# Altium schematic sheet CAPPA-B-DELUXE-esp.SchDoc(CAPPA-B-DELUXE-esp)
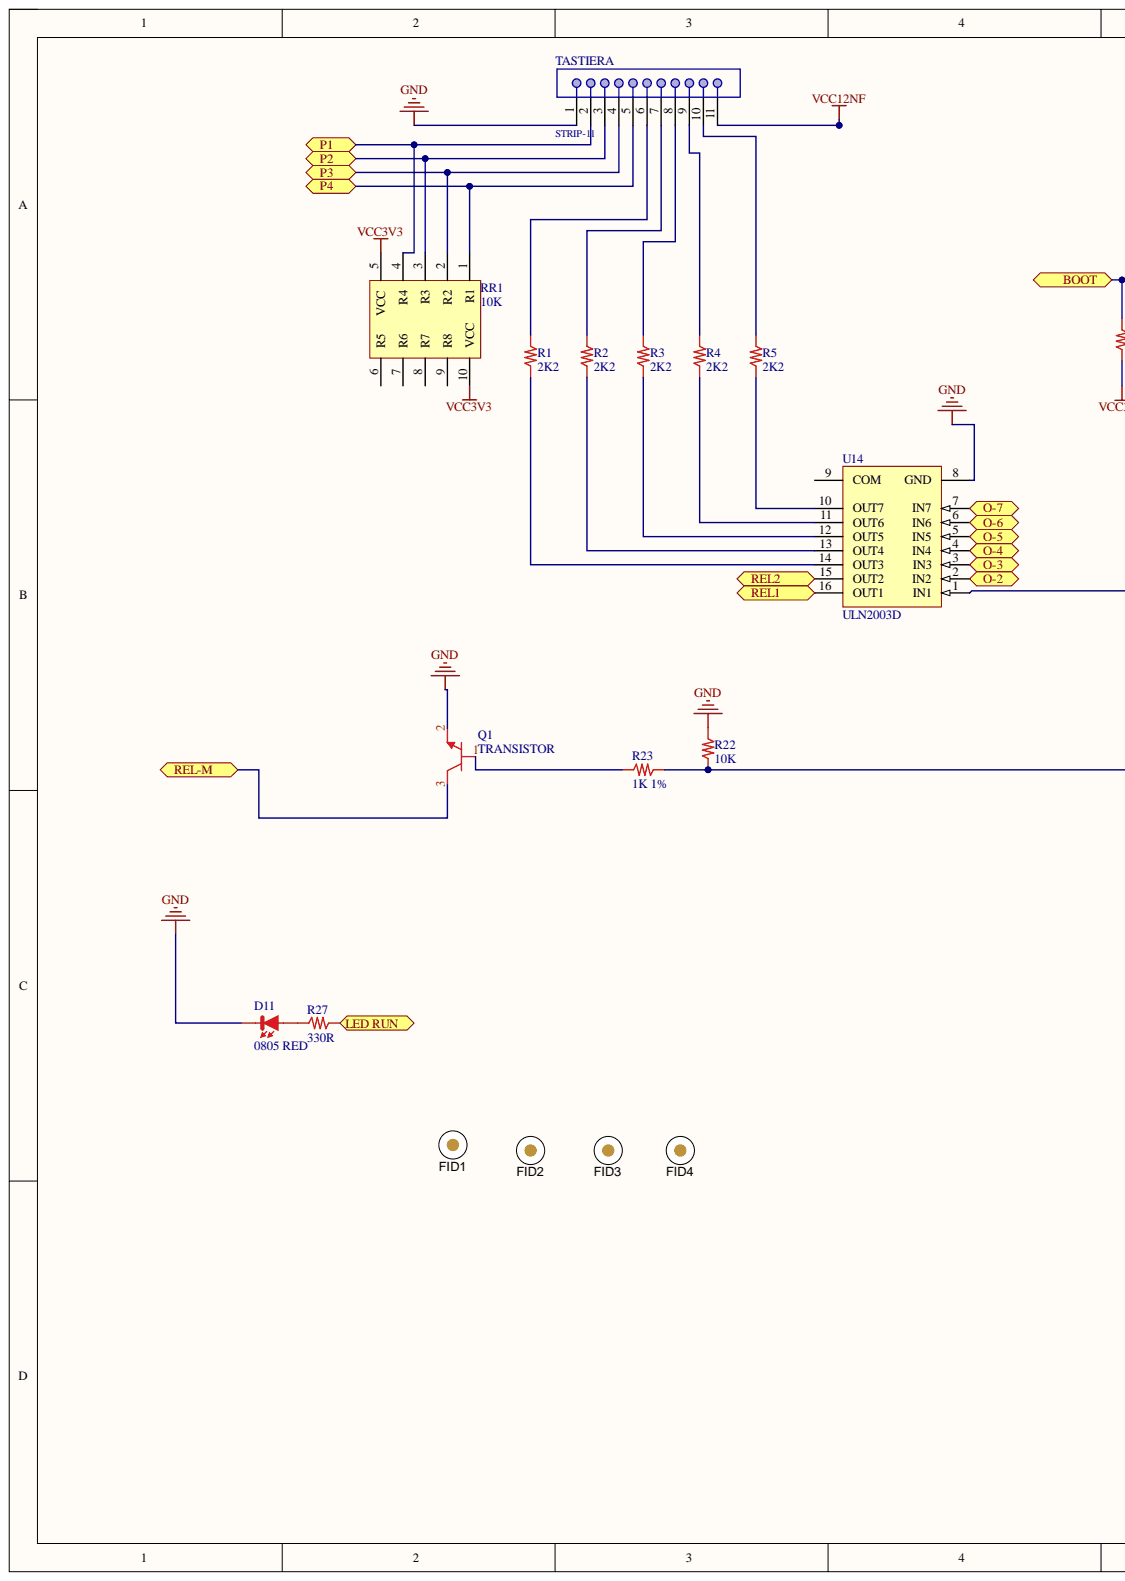
[diagram p0: CAPPA-B-DELUXE-esp.SchDoc, left]
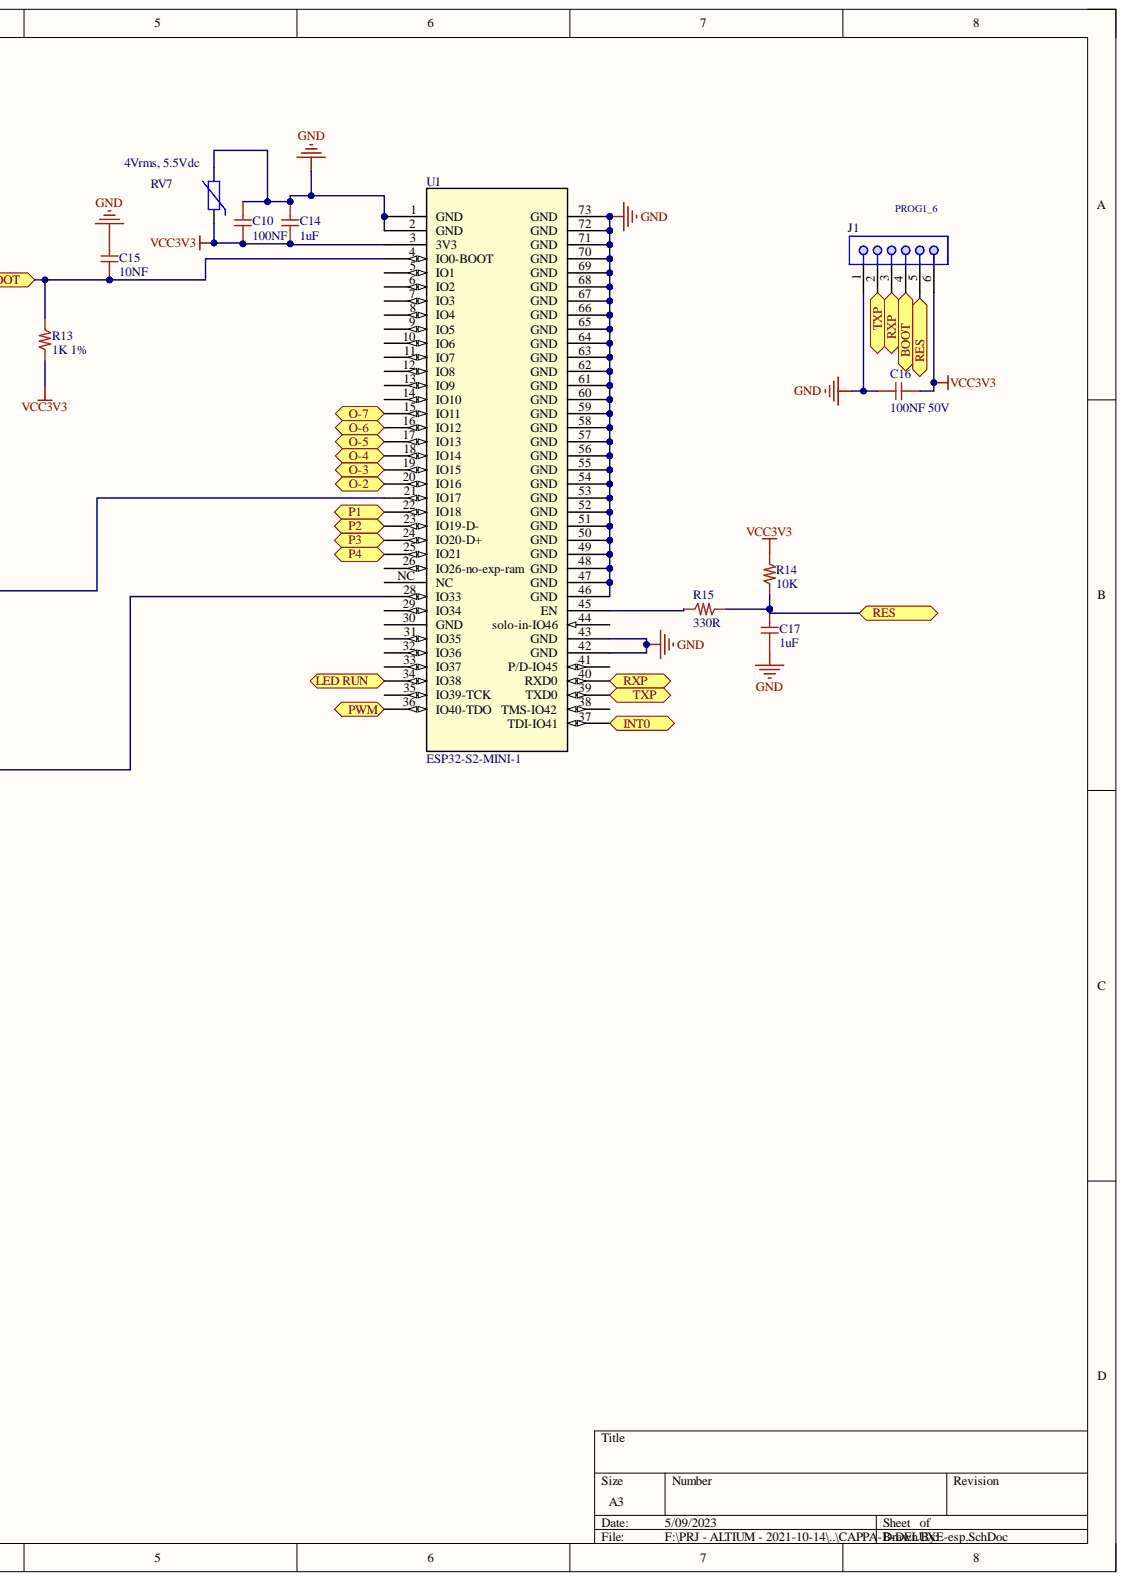
[diagram p1: CAPPA-B-DELUXE-esp.SchDoc, right]

## Parts
C10  100NF  [0402_C]
C14  1uF  [0603_c]
C15  10NF  [0402_C]
C16  100NF 50V  [0603_c]
C17  1uF  [0603_c]
D11  0805 RED  [0805_Red]
FID1  Fiducial  [Fiducial10-20]  (value not drawn)
FID2  Fiducial  [Fiducial10-20]  (value not drawn)
FID3  Fiducial  [Fiducial10-20]  (value not drawn)
FID4  Fiducial  [Fiducial10-20]  (value not drawn)
J1  PROG1_6  [PROG1 - TR -B]
Q1  TRANSISTOR  [SOT23]
R1  2K2  [1206_R]
R2  2K2  [1206_R]
R3  2K2  [1206_R]
R4  2K2  [1206_R]
R5  2K2  [1206_R]
R13  1K 1%  [0402_R]
R14  10K  [0603_R]
R15  330R  [0603_R]
R22  10K  [0603_R]
R23  1K 1%  [0603_R]
R27  330R  [0603_R]
RR1  10K  [RR8+1-SMD]
RV7  4Vrms, 5.5Vdc  DC Operating Voltage: 5.5Vdc  [WE-VS_0603]
TASTIERA  STRIP-11  [STRIP-11]
U1  ESP32-S2-MINI-1  [ESP32-S2-MINI-1]
U14  ULN2003D  [SO16_N]

## Ports
BOOT, INT0, LED RUN, O-2, O-3, O-4, O-5, O-6, O-7, P1, P2, P3, P4, PWM, REL1, REL2, REL-M, RES, RXP, TXP

## Nets
GND: C10.2 C14.2 C15.2 C16.2 C17.1 D11.K J1.1 Q1.2 R22.1 RV7.2 TASTIERA.1 U1.1(GND) U1.2(GND) U1.42(GND) U1.43(GND) U1.46(GND) U1.47(GND) U1.48(GND) U1.49(GND) U1.50(GND) U1.51(GND) U1.52(GND) U1.53(GND) U1.54(GND) U1.55(GND) U1.56(GND) U1.57(GND) U1.58(GND) U1.59(GND) U1.60(GND) U1.61(GND) U1.62(GND) U1.63(GND) U1.64(GND) U1.65(GND) U1.66(GND) U1.67(GND) U1.68(GND) U1.69(GND) U1.70(GND) U1.71(GND) U1.72(GND) U1.73(GND) U14.8(GND)
VCC3V3: C10.1 C14.1 C16.1 J1.6 R13.1 R14.1 RR1.5(VCC) RR1.10(VCC) RV7.1 U1.3(3V3)
VCC12NF: TASTIERA.11
BOOT: C15.1 J1.4 R13.2 U1.4(IO0-BOOT) ; port BOOT
INT0: U1.37(TDI-IO41) ; port INT0
LED RUN: R27.1 U1.34(IO38) ; port LED RUN
O-2: U1.20(IO16) U14.2(IN2) ; port O-2
O-3: U1.19(IO15) U14.3(IN3) ; port O-3
O-4: U1.18(IO14) U14.4(IN4) ; port O-4
O-5: U1.17(IO13) U14.5(IN5) ; port O-5
O-6: U1.16(IO12) U14.6(IN6) ; port O-6
O-7: U1.15(IO11) U14.7(IN7) ; port O-7
P1: RR1.4(R4) TASTIERA.2 U1.22(IO18) ; port P1
P2: RR1.3(R3) TASTIERA.3 U1.23(IO19-D-) ; port P2
P3: RR1.2(R2) TASTIERA.4 U1.24(IO20-D+) ; port P3
P4: RR1.1(R1) TASTIERA.5 U1.25(IO21) ; port P4
PWM: U1.36(IO40-TDO) ; port PWM
REL1: U14.16(OUT1) ; port REL1
REL2: U14.15(OUT2) ; port REL2
REL-M: Q1.3 ; port REL-M
RES: C17.2 J1.5 R14.2 R15.2 ; port RES
RXP: J1.3 U1.40(RXD0) ; port RXP
TXP: J1.2 U1.39(TXD0) ; port TXP
NetD11_A: D11.A R27.2
NetQ1_1: Q1.1 R23.1
NetR1_1: R1.1 U14.14(OUT3)
NetR1_2: R1.2 TASTIERA.6
NetR2_1: R2.1 U14.13(OUT4)
NetR2_2: R2.2 TASTIERA.7
NetR3_1: R3.1 U14.12(OUT5)
NetR3_2: R3.2 TASTIERA.8
NetR4_1: R4.1 U14.11(OUT6)
NetR4_2: R4.2 TASTIERA.9
NetR5_1: R5.1 U14.10(OUT7)
NetR5_2: R5.2 TASTIERA.10
NetR15_1: R15.1 U1.45(EN)
NetR22_2: R22.2 R23.2 U1.28(IO33)
NetU1_21: U1.21(IO17) U14.1(IN1)

Not connected: RR1 6(R5) 7(R6) 8(R7) 9(R8); U1 5(IO1) 6(IO2) 7(IO3) 8(IO4) 9(IO5) 10(IO6) 11(IO7) 12(IO8) 13(IO9) 14(IO10) 26(IO26-no-exp-ram) 29(IO34) 30(GND) 31(IO35) 32(IO36) 33(IO37) 35(IO39-TCK) 38(TMS-IO42) 41(P/D-IO45) 44(solo-in-IO46) NC; U14 9(COM)

## Library data of the parts
C10 = 100NF 16V: Cap X7R 100NF 16V; Cod. Interno: COCS556561600104; Cod. Produttore: ??; Component Kind: Standard; Library Name: CapSMD0402.SchLib; Produttore: ??
C14 C17 = 1uF 10V: 2.2 µF, 10 V, ± 10%, X5R 0603; Cod. Interno: COCS??; Cod. Produttore: 0603X225K100CT; Costo 100: 0,0436; Costo 1000: 0,0183; Produttore: WALSIN; Component Kind: Standard; ComponentLink1Description: FARNELL; ComponentLink1URL: http://it.farnell.com/walsin/0603x225k100ct/cond-mlcc-x5r-2-2uf-10v-0603-bob/dp/2495422?st=2.2uf; Library Name: CapSMD0603.SchLib
C15 = 10NF 50V: Cap X7R 10NF 50V; Cod. Interno: COCS556565000103; Cod. Produttore: ??; Component Kind: Standard; Library Name: CapSMD0402.SchLib; Produttore: ??
C16 = 100NF 50V: Cap X7R 100nF 50V Flex Terminal; Cod. Interno: COCS50665050104; Cod. Produttore: CL10B104KB8SFNC; Produttore: Samsung; Cod. DigiKey: 1276-1936-2-ND; Component Kind: Standard; Library Name: CapSMD0603.SchLib
D11 = LED_ROSSO_0805: LED Rosso 0805; Cod. Interno: LEDS 2AC080RS7500; Cod. Produttore: 150080RS75000; Luminosità: 150mcd; Produttore: WE; V: 2.0V; Component Kind: Standard; Library Name: DiodiLED.SchLib
FID1-FID4 = Fiducial: Fiducial mark.  There is nothing to buy or mount.; SymbolCreator: codlsc; ClassName: FiducialMark; Component Kind: Standard; CompType: Fiducial; LatestRevisionDate: 2015-10-18; LatestRevisionNote: Added Alternate PartNumber and Alternate Manufacturer parameters to appropriate components.; Manufacturer: N/A; MountType: N/A; PackageReference: N/A; PartNumber: N/A; SymbolVersion: 2009-11-4; Library Name: HSW.SchLib; Publisher: Texas Instruments
J1 = PROG1_6: SMT Vertical Pin Header WR-PHD, Pitch 2.54 mm, Single Row, 6 pins; Part Number: 61000618321; Contact Resistance: 20 mOhm; Gender: Male; Pin Number: 6; Pitch: 2.54 mm; Rated Current: 3 A; Withstanding Voltage: 500 VAC; Working Voltage: 250 VAC; Component Kind: Standard; ComponentLink1Description: Manufacturer; ComponentLink1URL: http://www.we-online.de; ComponentLink2Description: Datasheet; ComponentLink2URL: https://katalog.we-online.de/em/datasheet/6100xx18321.pdf; Mounting Technology: SMT; Operating Temperature: -40 to 125 degC; Orientation: Vertical; Packaging: Tube; Published Date: August 2018; Row Number: Single; Library Name: HSW.SchLib; Publisher: Wurth Elektronik
Q1 = BC847B: NPN Silicon Darlington Transistors; Cod. Interno: TRXS ??; Cod. Produttore: BCV27; Ic: 500mA; Produttore: INFINEON; Vcb: 40V; Vce: 30V; Component Kind: Standard; Library Name: HSW.SchLib
R1 R2 = 1K8: 1K8 1206 1/4W; Case: 1206; Component Kind: Standard; Library Name: ResSMD1206.SchLib
R3-R5 = 2K2: 2K2 1206 1/4W; Case: 1206; Component Kind: Standard; Library Name: ResSMD1206.SchLib
R13 = 1K 1%: Res Film Spesso 1/16W 1K 1%; Cod. Interno: RESS4165360C0103; Cod. Produttore: ??; Component Kind: Standard; Library Name: ResSMD0402.SchLib; Produttore: ??
R14 R22 = 10K: Res Film Spesso 1/16W 10K 5%; Cod. Interno: RESS4166556B0103??; Cod. Produttore: ??; Component Kind: Standard; Library Name: ResSMD0603.SchLib; Produttore: ??
R15 R27 = 330R: Res Film Spesso 1/16W 330R 5%; Cod. Interno: RESS4166556B0331; Component Kind: Standard; Library Name: ResSMD0603.SchLib
R23 = 1K 1%: Res Film Spesso 1/16W 1K 1%; Cod. Interno: RESS4166356B0102; Cod. Produttore: ??; Component Kind: Standard; Library Name: ResSMD0603.SchLib; Produttore: ??
RR1 = RETE RESISTIVA-8+1: RETE RESISTIVA 10K 8+1 1206 746X101103JP -CTS; Component Kind: Standard; Library Name: HSW.SchLib
RV7 = 82536040: WE-VS SMD Varistor, size 0603, 4Vrms, 5.5Vdc; Clamping Voltage: 21V; Energy Dissipation: 0.1J; Varistor Voltage: 8V; Withstanding Surge Current: 30A; AC Operating Voltage: 4Vrms; Component Kind: Standard; ComponentLink1Description: Manufacturer; ComponentLink1URL: http://www.we-online.de; ComponentLink2Description: Datasheet; ComponentLink2URL: https://katalog.we-online.com/pbs/datasheet/82536040.pdf; Library Name: WE-VS_0603.SchLib; Mounting Technology: SMT; Operating Temperature: -40 to +85degC; Packaging: Tape and Reel; Part Number: 82536040; Published Date: March 2019; Size: 0603; Publisher: Wurth Elektronik
TASTIERA = STRIP-11: THT Vertical Pin Header WR-PHD, Pitch 2.54 mm, Single Row, 12 pins; Part Number: 61301211121; Contact Resistance: 20 mOhm; Gender: Male; Pin Number: 12; Pitch: 2.54 mm; Rated Current: 3 A; Withstanding Voltage: 500 VAC; Working Voltage: 250 VAC; Component Kind: Standard; ComponentLink1Description: Manufacturer; ComponentLink1URL: http://www.we-online.de; ComponentLink2Description: Datasheet; ComponentLink2URL: https://katalog.we-online.de/em/datasheet/6130xx11121.pdf; Mounting Technology: THT; Operating Temperature: -40 to 105 degC; Orientation: Vertical; Packaging: Bag; Published Date: August 2018; Row Number: Single; Library Name: HSW.SchLib; Publisher: Wurth Elektronik
U1 = ESP32-S2-MINI-1: ESP32-S2FH4 embedded, Xtensa®single-core32-bit LX7 microprocessor, up to 240 MHzGPIO, SPI, LCD, UART, I2C, I2S,USBOTG 1.1, ADC, DAC, touch sensor, temperaturesensor; Built_By: EMA_Kyle; SourceLibrary: Add_to_database_2020-11-03; Vendor: Espressif Systems; Component Kind: Standard; Datasheet: https://www.espressif.com/sites/default/files/documentation/esp32-s2-mini-1_esp32-s2-mini-1u_datasheet_en.pdf; Manufacturer_Part_Number: ESP32-S2-MINI-1; RefDes: RefDes; Type: DEV; Copyright: Copyright (C) 2018 Accelerated Designs. All rights reserved; Library Name: HSW.SchLib
U14 = ULN2003D: Seven Darlington Array; Code_IPC: SOIC127P600-16; Component Kind: Standard; ComponentLink1Description: Manufacturer Link; ComponentLink1URL: http://www.st.com/; ComponentLink2Description: Datasheet; ComponentLink2URL: http://www.st.com/stonline/products/literature/ds/5279/uln2001.pdf; DatasheetVersion: Sep-1998; LatestRevisionDate: 13-Apr-2006; LatestRevisionNote: IPC-7351 Footprint Added.; PackageDescription: 16-Pin Small Outline Integrated Circuit 1.27 mm Pitch; PackageReference: SO16; PackageVersion: May-1998; Published: 8-Jun-2000; Library Name: HSW.SchLib; Publisher: Altium Limited
